# Altium schematic sheet MCU_ESP32.SchDoc
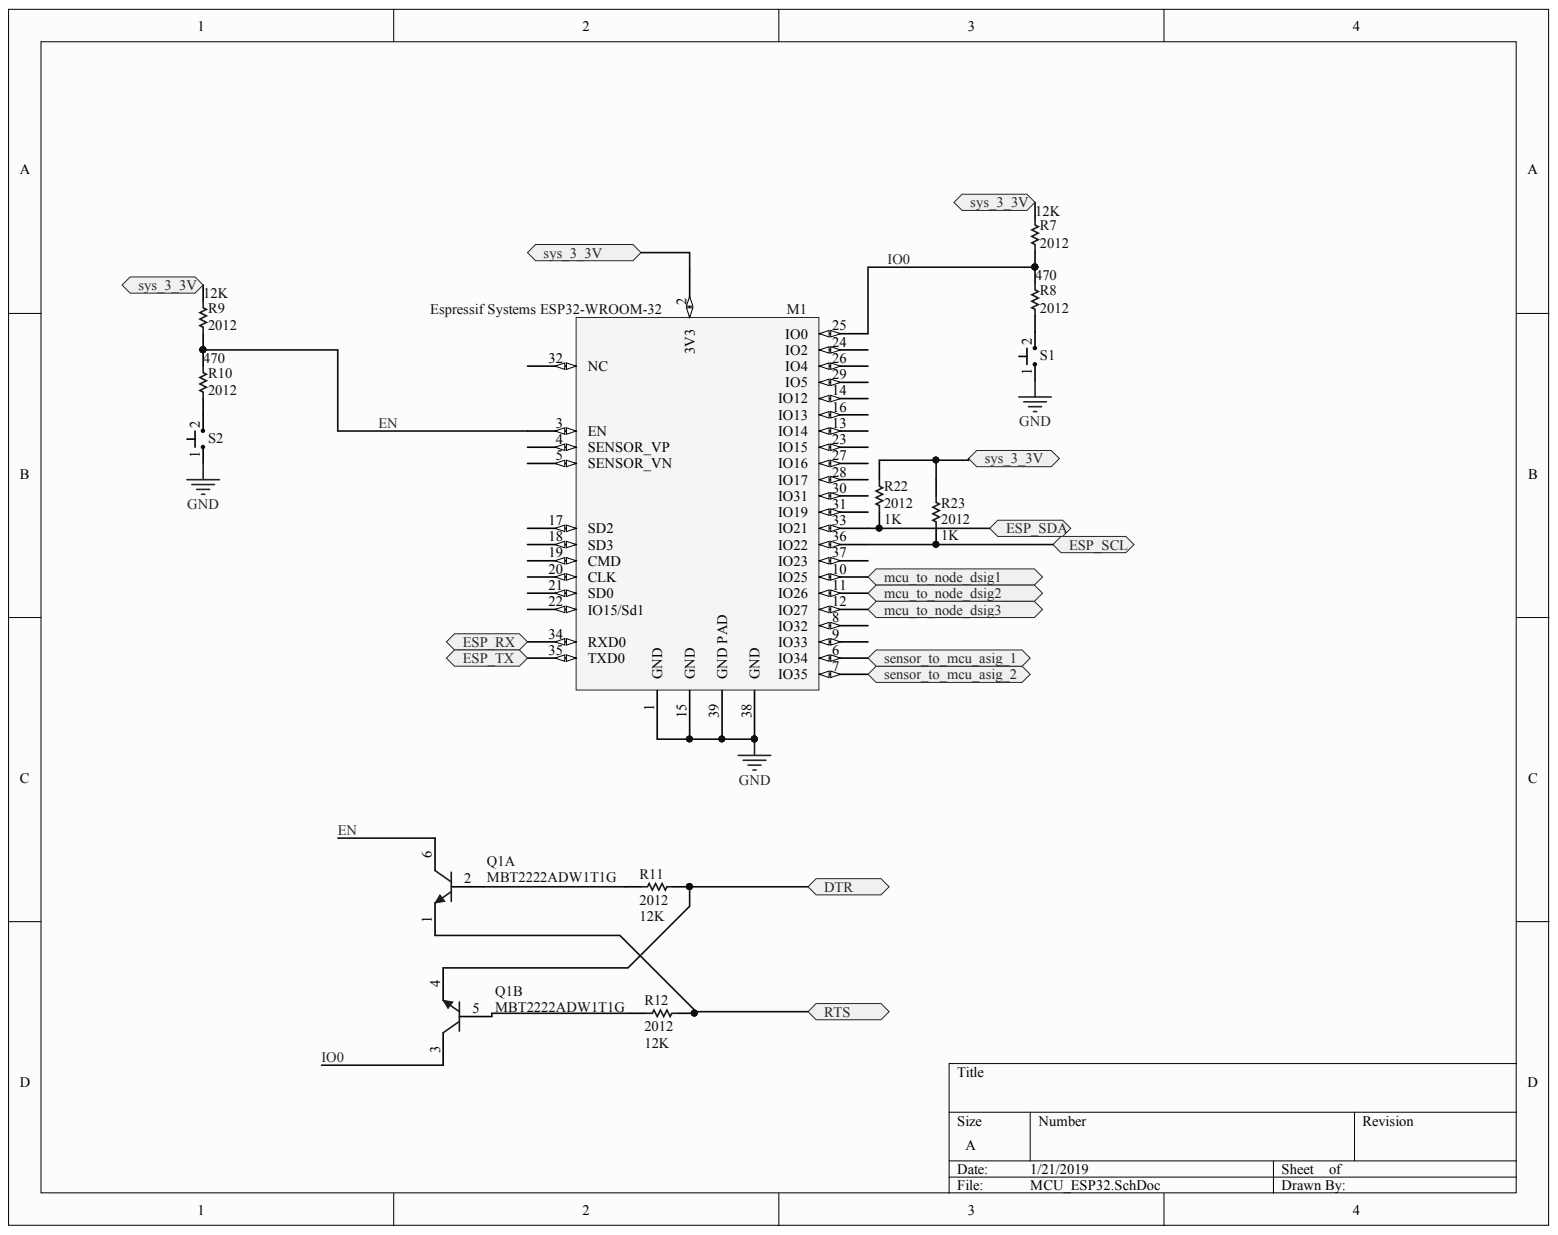
[diagram p0: MCU_ESP32.SchDoc]

## Parts
M1  Espressif Systems ESP32-WROOM-32  [PCB-2wsaxr5oyyiks99lfuw0-1]
Q1  MBT2222ADW1T1G  [ONSC-SOT-363-6-419B-02_V]  (parts A,B)
R7  Case/Package (SI): 2012  Resistance: 12K  [RESC2013X70X40ML20T20]  (hidden comment: Panasonic ERJ-6GEYJ822V)
R8  Case/Package (SI): 2012  [RESC2013X70X40ML20T20]  (hidden comment: Panasonic ERJ-6GEYJ822V)
R9  Case/Package (SI): 2012  Resistance: 12K  [RESC2013X70X40ML20T20]  (hidden comment: Panasonic ERJ-6GEYJ822V)
R10  Case/Package (SI): 2012  [RESC2013X70X40ML20T20]  (hidden comment: Panasonic ERJ-6GEYJ822V)
R11  Case/Package (SI): 2012  Resistance: 12K  [RESC2013X70X40ML20T20]  (hidden comment: Panasonic ERJ-6GEYJ822V)
R12  Case/Package (SI): 2012  Resistance: 12K  [RESC2013X70X40ML20T20]  (hidden comment: Panasonic ERJ-6GEYJ822V)
R22  Case/Package (SI): 2012  Resistance: 1K  [RESC2013X70X40ML20T20]  (hidden comment: Panasonic ERJ-6GEYJ822V)
R23  Case/Package (SI): 2012  Resistance: 1K  [RESC2013X70X40ML20T20]  (hidden comment: Panasonic ERJ-6GEYJ822V)
S1  TE Connectivity FSM4JH  [PCB-acd06xmd0wg5ueuxhpdp-1]  (value not drawn)
S2  TE Connectivity FSM4JH  [PCB-acd06xmd0wg5ueuxhpdp-1]  (value not drawn)

## Ports
DTR, ESP_RX, ESP_SCL, ESP_SDA, ESP_TX, MCU_TO_NODE_DSIG1, MCU_TO_NODE_DSIG2, MCU_TO_NODE_DSIG3, RTS, SENSOR_TO_MCU_ASIG_1, SENSOR_TO_MCU_ASIG_2, SYS_3_3V

## Nets
GND: M1.1(GND) M1.15(GND) M1.38(GND) M1.39(GND_PAD) S1.1 S2.1
MCU_TO_NODE_DSIG1: M1.10(IO25) ; port MCU_TO_NODE_DSIG1
MCU_TO_NODE_DSIG2: M1.11(IO26) ; port MCU_TO_NODE_DSIG2
MCU_TO_NODE_DSIG3: M1.12(IO27) ; port MCU_TO_NODE_DSIG3
SENSOR_TO_MCU_ASIG_1: M1.6(IO34) ; port SENSOR_TO_MCU_ASIG_1
SENSOR_TO_MCU_ASIG_2: M1.7(IO35) ; port SENSOR_TO_MCU_ASIG_2
SYS_3_3V: M1.2(3V3) R7.2 R9.2 R22.1 R23.1 ; port SYS_3_3V
DTR: Q1.4 R11.2 ; port DTR
EN: M1.3(EN) Q1.6 R9.1 R10.2
ESP_RX: M1.34(RXD0) ; port ESP_RX
ESP_SCL: M1.36(IO22) R23.2 ; port ESP_SCL
ESP_SDA: M1.33(IO21) R22.2 ; port ESP_SDA
ESP_TX: M1.35(TXD0) ; port ESP_TX
IO0: M1.25(IO0) Q1.3 R7.1 R8.2
RTS: Q1.1 R12.1 ; port RTS
NetQ1_2: Q1.2 R11.1
NetQ1_5: Q1.5 R12.2
NetR8_1: R8.1 S1.2
NetR10_1: R10.1 S2.2

Not connected: M1 4(SENSOR_VP) 5(SENSOR_VN) 8(IO32) 9(IO33) 13(IO14) 14(IO12) 16(IO13) 17(SD2) 18(SD3) 19(CMD) 20(CLK) 21(SD0) 22(IO15/Sd1) 23(IO15) 24(IO2) 26(IO4) 27(IO16) 28(IO17) 29(IO5) 30(IO31) 31(IO19) 32(NC) 37(IO23)

## Library data of the parts
M1 = CMP-ba1676706f1765d1-4: Wi-Fi/BT BLE Module ESP32-D0WDQ6, SPI Flash, UART; Component Kind: Standard; Library Name: CommunityVault; Octopart URL: https://octopart.com/esp32-wroom-32-espressif+systems-91025511
Q1 = CMP-854660-2: General Purpose Transistor, NPN Silicon, 6-Pin SOT-363, Pb-Free, Tape and Reel; Current Rating: 0.6 A; Lead-Free Status: Lead Free; Voltage Rating (DC): 40.0 V; Case/Package: SOT-363; Component Kind: Standard; Halogen Free Status: Halogen Free; Library Name: CommunityVault; Lifecycle Status: Active; Manufacturer Part Number: MBT2222ADW1T1G; Mounting Style: Surface Mount; Number of Pins: 6; OctopartID: 1f01d6078701c0c6; Octopart Url: https://octopart.com/mbt2222adw1t1g-on+semiconductor-834918; Packaging: Tape; REACH SVHC Compliance: No SVHC; RoHS: Compliant
R7 R9 R11 R12 R22 R23 = CMP-3687578-2: Thick Film Resistors - SMD 0805 8.2Kohms 5% Tol; Lead-Free Status: Lead Free; Power Rating: 0.125 W; Voltage Rating (DC): 150.0 V; Case/Package: 0805; Component Kind: Standard; Library Name: CommunityVault; Lifecycle Status: Not Listed by Manufacturer; Manufacturer Part Number: ERJ-6GEYJ822V; Mounting Style: Surface Mount; OctopartID: 0556cf11906ada91; Octopart Url: https://octopart.com/erj-6geyj822v-panasonic-55423066; Packaging: Cut Tape (CT); Resistance Tolerance: ±5%; RoHS: Compliant; Size-Height: 0.0006 m; Size-Length: 0.002 m; Size-Width: 0.00125 m
R8 R10 = CMP-3687578-2: Thick Film Resistors - SMD 0805 8.2Kohms 5% Tol; Lead-Free Status: Lead Free; Power Rating: 0.125 W; Resistance: 470; Voltage Rating (DC): 150.0 V; Case/Package: 0805; Component Kind: Standard; Library Name: CommunityVault; Lifecycle Status: Not Listed by Manufacturer; Manufacturer Part Number: ERJ-6GEYJ822V; Mounting Style: Surface Mount; OctopartID: 0556cf11906ada91; Octopart Url: https://octopart.com/erj-6geyj822v-panasonic-55423066; Packaging: Cut Tape (CT); Resistance Tolerance: ±5%; RoHS: Compliant; Size-Height: 0.0006 m; Size-Length: 0.002 m; Size-Width: 0.00125 m
S1 S2 = CMP-441d8f7279709bda-7: Switch Tactile OFF (ON) Round Button PC Pins 0.05A 12VDC 1.57N Thru-Hole Radial Tape; Contact Current Rating: 50.0 mA; Contacts Type: SPST; Component Kind: Standard; Library Name: CommunityVault
